# Altium schematic sheet 5) BLU.SchDoc("5) BLU")
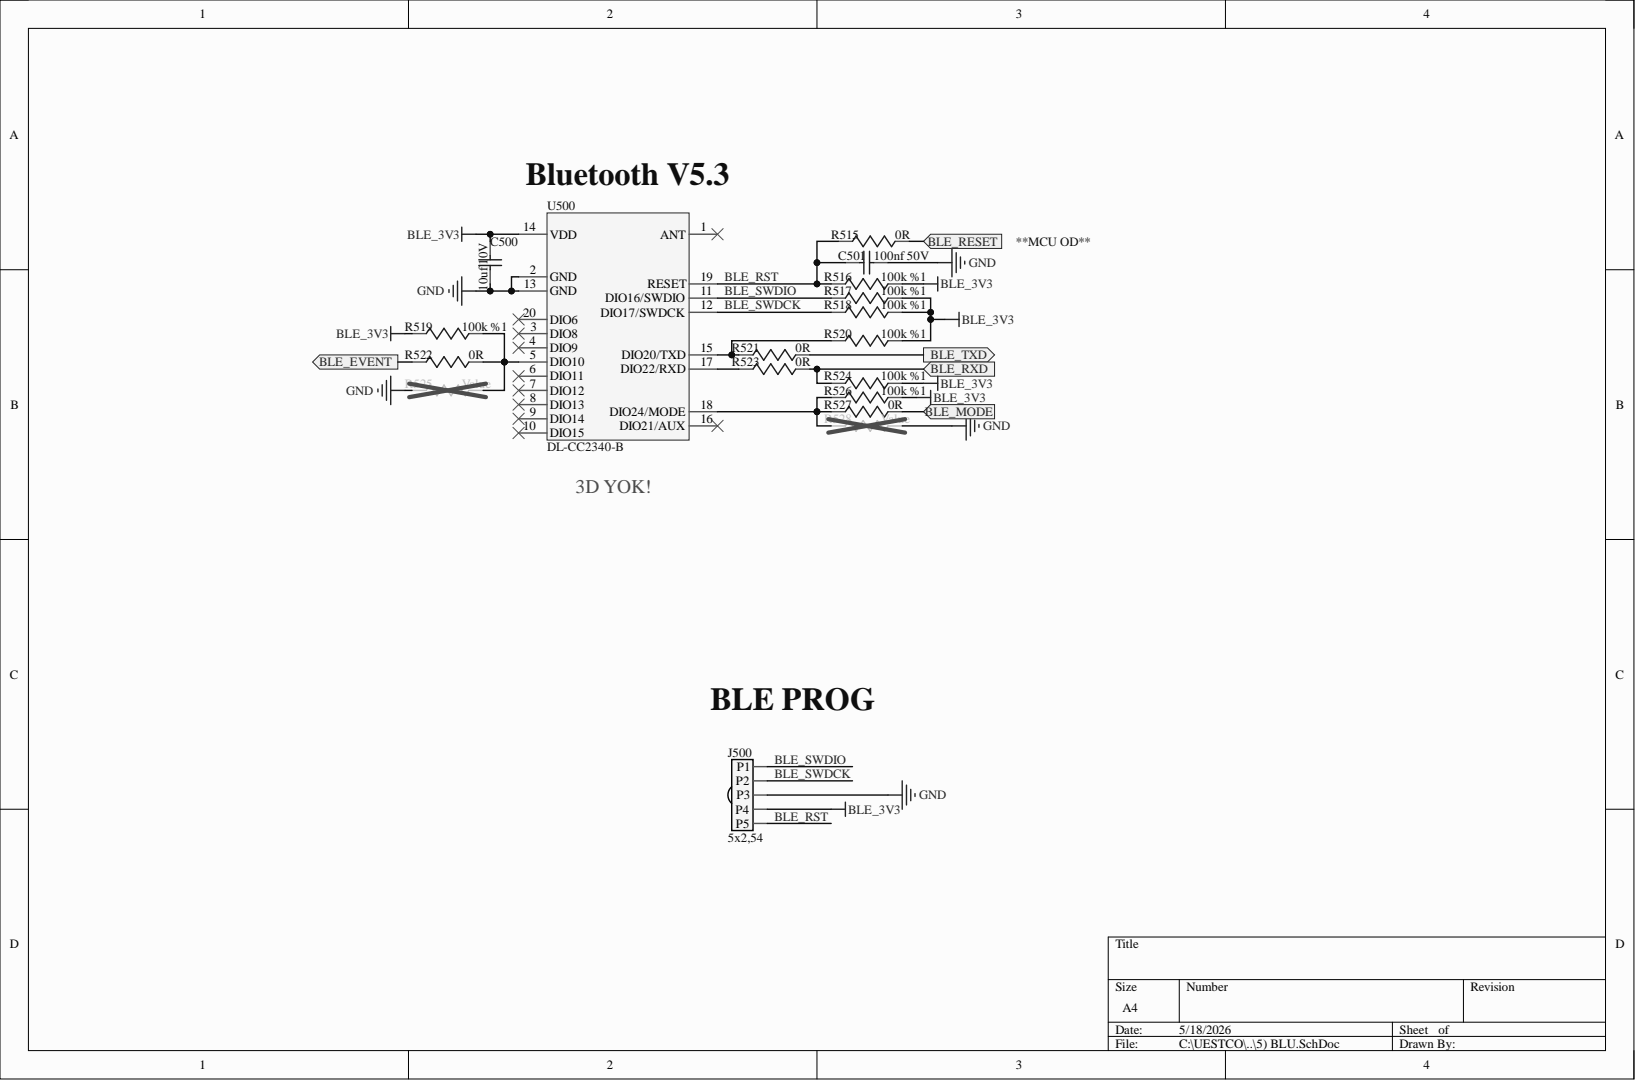
[diagram p0: 5) BLU.SchDoc]

## Parts
C500  10uf 10V  [C0603]
C501  100nf 50V  [C0402]
J500  5x2,54  [CONN 5x2.54MM]
R515  0R  [R0402]
R516  100k %1  [R0402]
R517  100k %1  [R0402]
R518  100k %1  [R0402]
R519  100k %1  [R0402]
R520  100k %1  [R0402]
R521  0R  [R0402]
R522  0R  [R0402]
R523  0R  [R0402]
R524  100k %1  [R0402]
R525  100k %1  [R0402]
R526  100k %1  [R0402]
R527  0R  [R0402]
R528  100k %1  [R0402]
U500  DL-CC2340-B  [DL-CC2340-B]

## Ports
BLE_EVENT, BLE_MODE, BLE_RESET, BLE_RXD, BLE_TXD

## Nets
BLE_3V3: C500.2 J500.4(P4) R516.1 R517.1 R518.1 R519.1 R520.1 R524.1 R526.1 U500.14(VDD)
GND: C500.1 C501.1 J500.3(P3) R525.1 R528.1 U500.2(GND) U500.13(GND)
BLE_EVENT: R522.1 ; port BLE_EVENT
BLE_MODE: R527.2 ; port BLE_MODE
BLE_RESET: R515.2 ; port BLE_RESET
BLE_RST: C501.2 J500.5(P5) R515.1 R516.2 U500.19(RESET)
BLE_RXD: R523.2 R524.2 ; port BLE_RXD
BLE_SWDCK: J500.2(P2) R518.2 U500.12(DIO17/SWDCK)
BLE_SWDIO: J500.1(P1) R517.2 U500.11(DIO16/SWDIO)
BLE_TXD: R521.2 ; port BLE_TXD
NetR519_2: R519.2 R522.2 R525.2 U500.5(DIO10)
NetR520_2: R520.2 R521.1 U500.15(DIO20/TXD)
NetR523_1: R523.1 U500.17(DIO22/RXD)
NetR526_2: R526.2 R527.1 R528.2 U500.18(DIO24/MODE)

Not connected: U500 1(ANT) 3(DIO8) 4(DIO9) 6(DIO11) 7(DIO12) 8(DIO13) 9(DIO14) 10(DIO15) 16(DIO21/AUX) 20(DIO6)

## Library data of the parts
C500 = CAP 10uf 10V %10 0603: CAP CER 10UF 10V X5R 0603; Manufacturer Part Number: CC0603MRX5R6BB106; Component Kind: Standard; Library Name: PCBGSM910G2.SCHLIB; Value: 10uf 10V
C501 = CAP 100nf 50V %10 0402: CAP CER 0.1UF 50V X5R 0402; Manufacturer Part Number: CC0402KRX5R9BB104; Component Kind: Standard; Library Name: PCBGSM910G2.SCHLIB; Value: 100nf 50V
J500 = CONN 5x2,54mm Pin header: PIN HEADER 40PIN(1x40) 2.54mm THT V/T; Manufacturer Part Number: KLS1-207-1-40-S-3X5.5X11; Component Kind: Standard; Library Name: PCBPGD810G3B1.SCHLIB; Value: 5x2,54
R515 R521-R523 R527 = RES 0R 1/16W 0402: RES SMD 0 OHM JUMPER 1/16W 0402; Manufacturer Part Number: RC0402JR-070RL; Component Kind: Standard; Library Name: PCBGSM910G2.SCHLIB; Value: 0R
R516-R520 R524-R526 R528 = RES 100K %1 1/16W 0402: RES SMD 100K OHM 1% 1/16W 0402; Manufacturer Part Number: RC0402FR-07100KL; Component Kind: Standard; Library Name: PCBGSM910G2.SCHLIB; Value: 100k %1
U500 = RF DL-CC2340-B: DL-CC2340-B BLE Transceiver Module; Component Kind: Standard; Library Name: PCBPGD810G3B1.SCHLIB; Manufacturer Part Number: DL-CC2340-B; Value: DL-CC2340-B
